# Altium schematic sheet Connectors.SchDoc
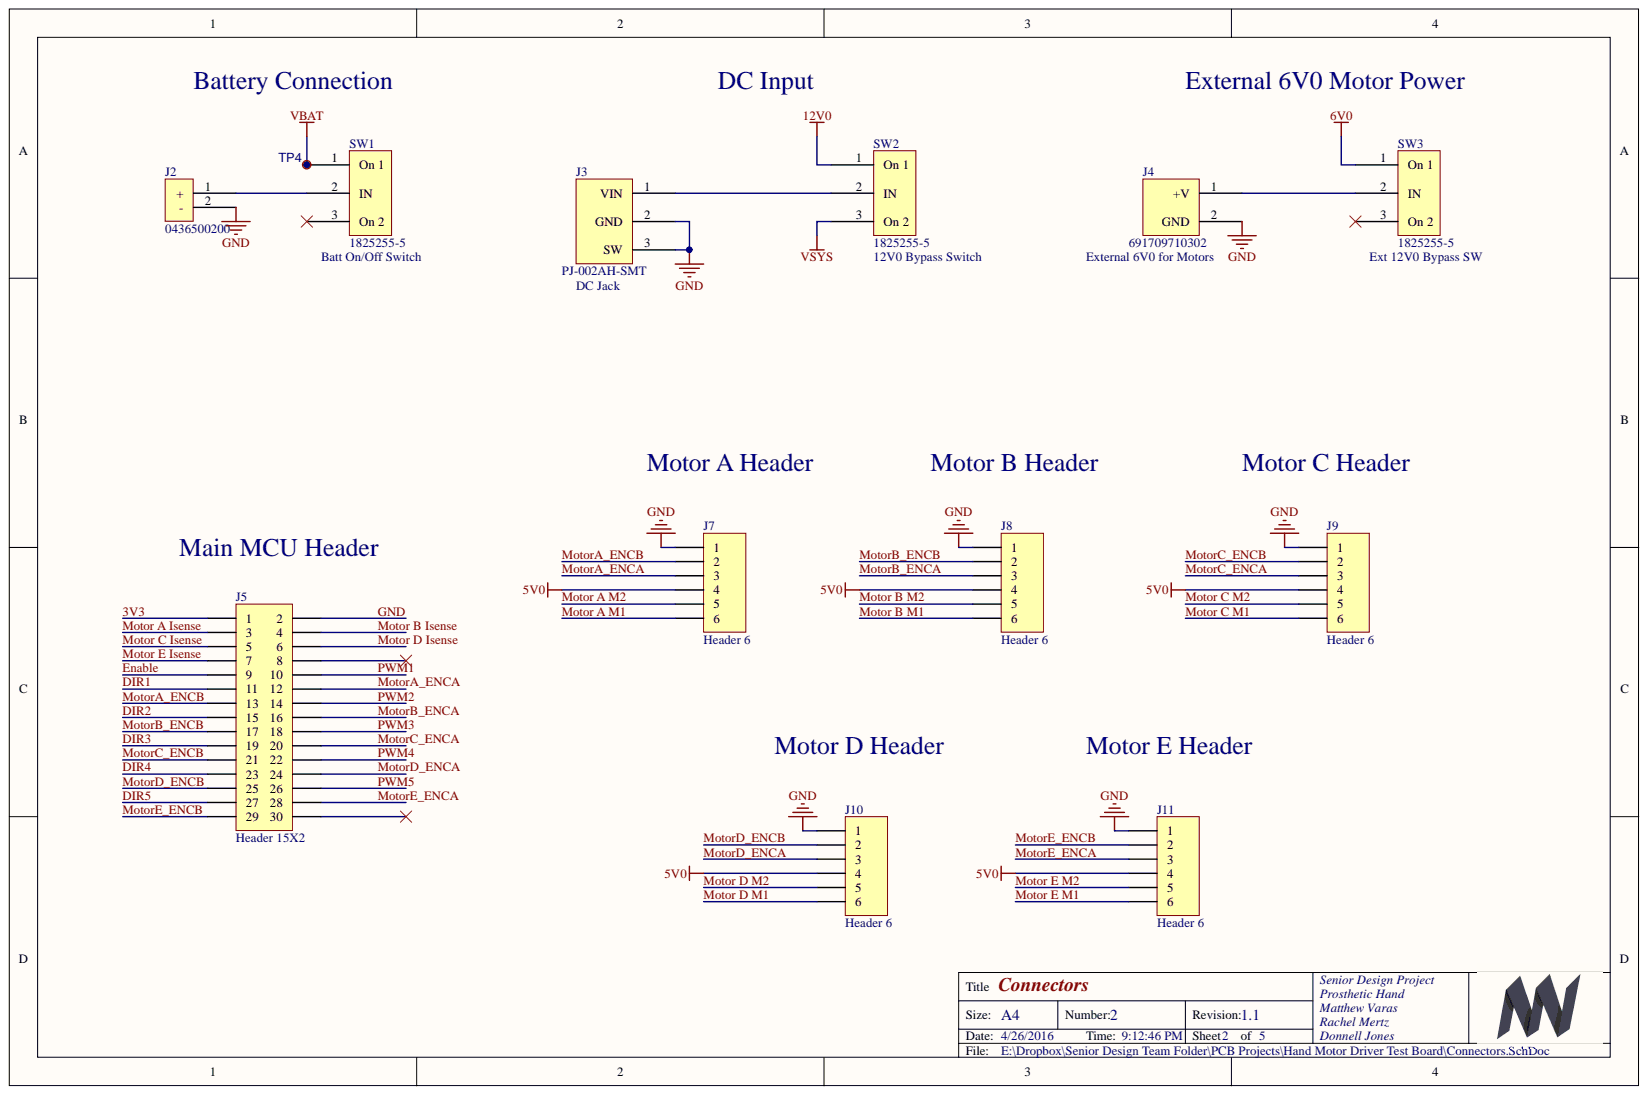
[diagram p0: Connectors.SchDoc]

## Parts
J2  0436500200  [43650-0200]
J3  PJ-002AH-SMT  [PJ-002AH-SMT]
J4  691709710302  [2-Pin Screw Terminal]
J5  Header 15X2  [HDR2X15]
J7  Header 6  [HDR1X6]
J8  Header 6  [HDR1X6]
J9  Header 6  [HDR1X6]
J10  Header 6  [HDR1X6]
J11  Header 6  [HDR1X6]
SW1  1825255-5  [On/Off/On Slider Switch]
SW2  1825255-5  [On/Off/On Slider Switch]
SW3  1825255-5  [On/Off/On Slider Switch]
TP4  Test Point  [Testpoint_70]  (value not drawn)

## Nets
5V0: J7.4 J8.4 J9.4 J10.4 J11.4
6V0: SW3.1
12V0: SW2.1
VBAT: SW1.1 TP4.1
VSYS: SW2.3
3V3: J5.1
DIR1: J5.11
DIR2: J5.15
DIR3: J5.19
DIR4: J5.23
DIR5: J5.27
ENABLE: J5.9
GND: J2.2 J3.2 J3.3 J4.2 J5.2 J7.1 J8.1 J9.1 J10.1 J11.1
MOTOR A ISENSE: J5.3
MOTOR A M1: J7.6
MOTOR A M2: J7.5
MOTOR B ISENSE: J5.4
MOTOR B M1: J8.6
MOTOR B M2: J8.5
MOTOR C ISENSE: J5.5
MOTOR C M1: J9.6
MOTOR C M2: J9.5
MOTOR D ISENSE: J5.6
MOTOR D M1: J10.6
MOTOR D M2: J10.5
MOTOR E ISENSE: J5.7
MOTOR E M1: J11.6
MOTOR E M2: J11.5
MOTORA_ENCA: J5.12 J7.3
MOTORA_ENCB: J5.13 J7.2
MOTORB_ENCA: J5.16 J8.3
MOTORB_ENCB: J5.17 J8.2
MOTORC_ENCA: J5.20 J9.3
MOTORC_ENCB: J5.21 J9.2
MOTORD_ENCA: J5.24 J10.3
MOTORD_ENCB: J5.25 J10.2
MOTORE_ENCA: J5.28 J11.3
MOTORE_ENCB: J5.29 J11.2
PWM1: J5.10
PWM2: J5.14
PWM3: J5.18
PWM4: J5.22
PWM5: J5.26
NetJ2_1: J2.1 SW1.2
NetJ3_1: J3.1 SW2.2
NetJ4_1: J4.1 SW3.2

Not connected: J5 8 30; SW1 3; SW3 3

## Library data of the parts
J2 = Battery Connector: CONN HEADER 2POS 3MM RT ANG TIN; DIGI_PN01: WM1860-ND; MFG_NAME01: Molex; Component Kind: Standard; MFG_PN01: 43650-0200; Library Name: Prosthetic Hand Schematic Lib.SchLib
J3 = PJ-002AH-SMT: CONN POWER JACK 2.1X5.5MM HI CUR; DIGI_PN01: CP-002AHPJCT-ND; MFG_NAME01: CUI Inc.; MFG_PN01: PJ-002AH-SMT-TR; : DC Jack; Component Kind: Standard; Library Name: Prosthetic Hand Schematic Lib.SchLib
J4 = Screw Terminal 2-pin: CONN TERM BLOCK 2POS 5MM BLACK; DIGI_PN01: 1297-1108-1-ND; MFG_NAME01: Wurth Electronics Inc; : External 6V0 for Motors; Component Kind: Standard; MFG_PN01: 691709710302; Library Name: Prosthetic Hand Schematic Lib.SchLib
J5 = Header 15X2: 30 Position Receptacle Connector 0.100" (2.54mm) Through Hole Tin; DIGI_PN01: SAM1212-15-ND; MFG_NAME01: Samtec Inc; MFG_PN01: SSW-115-01-T-D; Component Kind: Standard; LatestRevisionDate: 17-Jul-2002; LatestRevisionNote: Re-released for DXP Platform.; Library Name: Miscellaneous Connectors.SchLib; Signal Integrity: Connector; Publisher: Altium Limited
J7-J11 = Header 6: CONN HEADER VERT 6POS .100 TIN; DIGI_PN01: A31116-ND; MFG_NAME01: TE Connectivity; MFG_PN01: 3-644456-6; Component Kind: Standard; LatestRevisionDate: 17-Jul-2002; LatestRevisionNote: Re-released for DXP Platform.; Library Name: Miscellaneous Connectors.SchLib; Signal Integrity: Connector; Publisher: Altium Limited
SW1-SW3 = 1825255-5: SWITCH SLIDE SPDT 300MA 125V; DIGI_PN01: 450-1569-ND; MFG_NAME01: TE Connectivity; Component Kind: Standard; MFG_PN01: 1825255-5; Library Name: Prosthetic Hand Schematic Lib.SchLib
  SW1: : Batt On/Off Switch
  SW2: : 12V0 Bypass Switch
  SW3: : Ext 12V0 Bypass SW
TP4 = Test Point: Test Pad; MFG_NAME01: DNP; Component Kind: Standard; DNP: DNP; MFG_PN01: DNP; Library Name: Prosthetic Hand Schematic Lib.SchLib
